# Altium schematic sheet glucometer_schematic.SchDoc
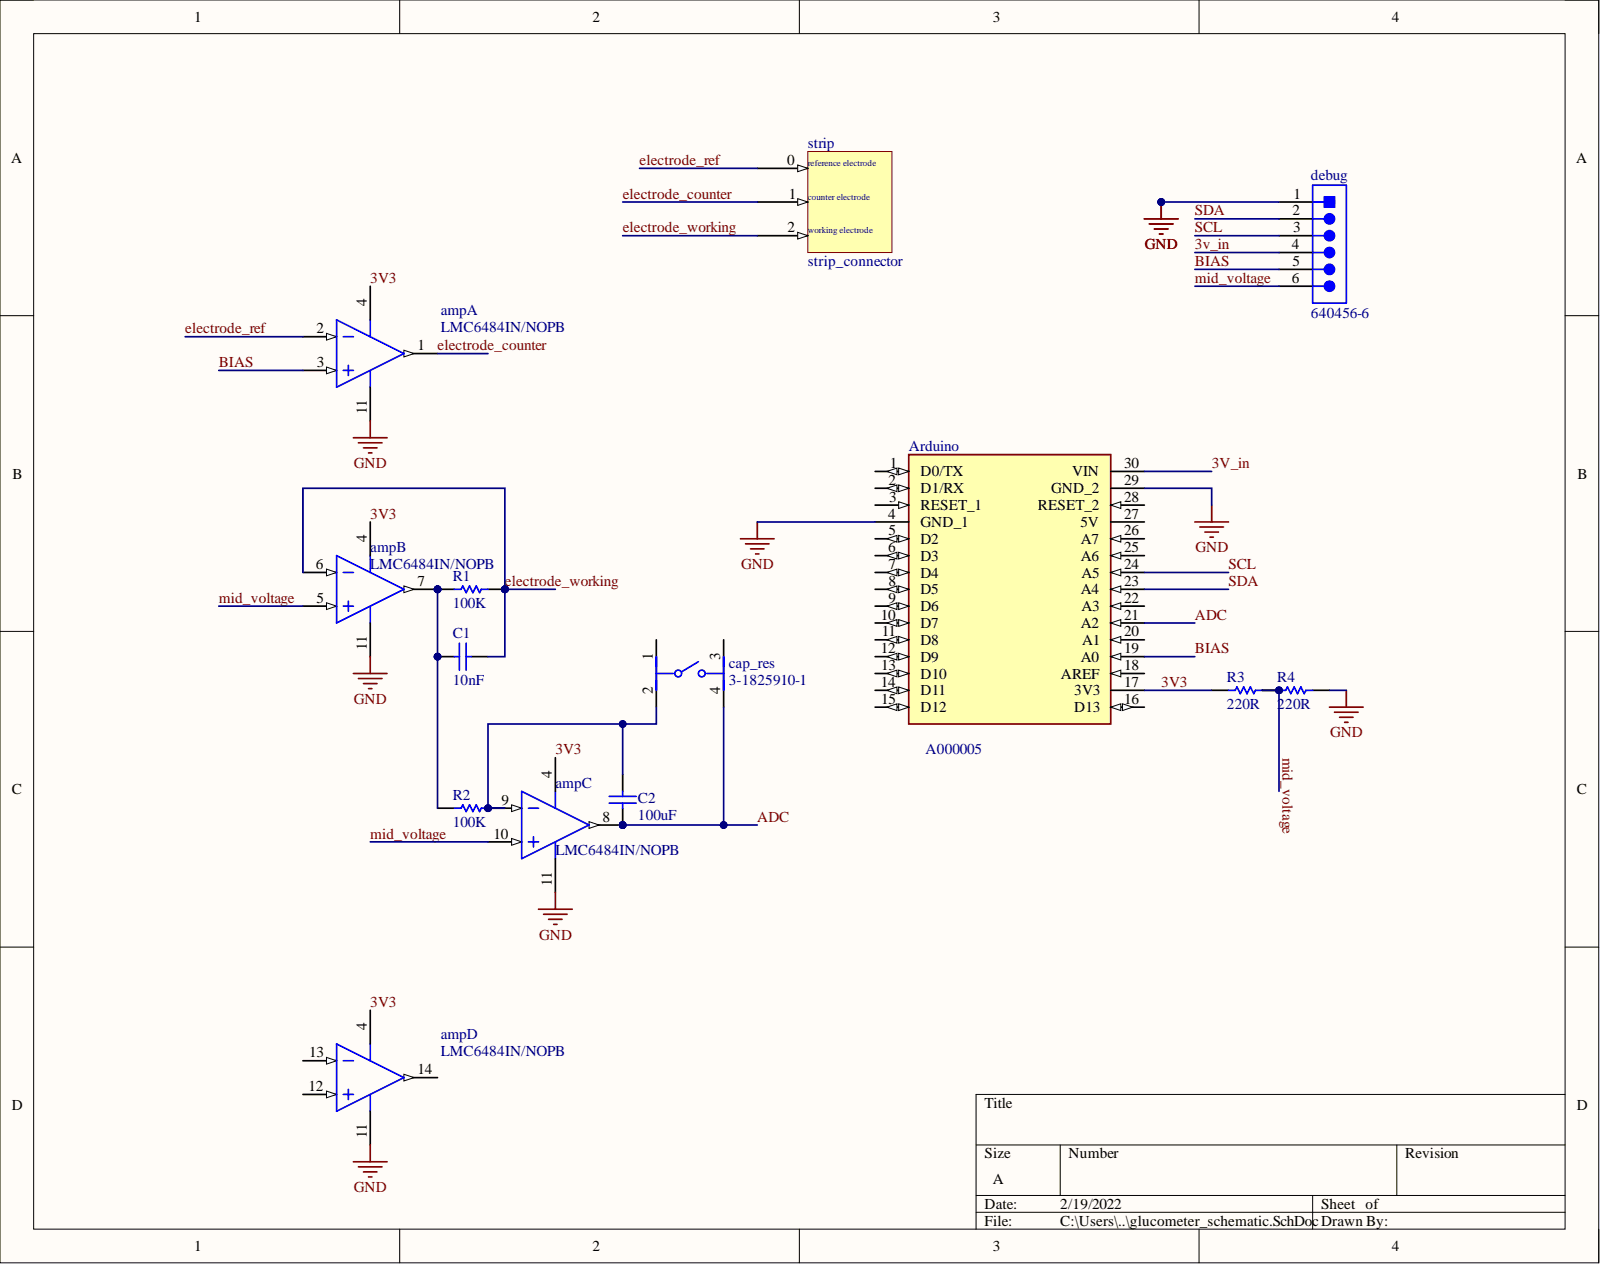
[diagram p0: glucometer_schematic.SchDoc]

## Parts
amp  LMC6484IN/NOPB  [NFF0014A]  (parts A,B,C,D)
Arduino  A000005  MF: Arduino  [MODULE_A000005]
C1  10nF  [KEMT-C330C105M5U5TA]
C2  100uF  [KEMT-C330C105M5U5TA]
cap_res  3-1825910-1  [FP-3-1825910-1-MFG]
debug  640456-6  [TECO-640456-6_V]
R1  100K  [RESA60-630X240]
R2  100K  [RESA60-630X240]
R3  220R  [RESA60-630X240]
R4  220R  [RESA60-630X240]
strip  strip_connector  [strip_connector]

## Nets
GND: amp.11 Arduino.4(GND_1) Arduino.29(GND_2) debug.1 R4.1
3V3: amp.4 Arduino.17(3V3) R3.2
3v_in: Arduino.30(VIN) debug.4
ADC: amp.8 Arduino.21(A2) C2.1 cap_res.4
BIAS: amp.3 Arduino.19(A0) debug.5
electrode_counter: amp.1 strip.1
electrode_ref: amp.2 strip.0
electrode_working: amp.6 C1.2 R1.2 strip.2
mid_voltage: amp.5 amp.10 debug.6 R3.1 R4.2
SCL: Arduino.24(A5) debug.3
SDA: Arduino.23(A4) debug.2
Netamp_7: amp.7 C1.1 R1.1 R2.1
Netamp_9: amp.9 C2.2 cap_res.2 R2.2

Not connected: amp 12 13 14; Arduino 1(D0/TX) 2(D1/RX) 3(RESET_1) 5(D2) 6(D3) 7(D4) 8(D5) 9(D6) 10(D7) 11(D8) 12(D9) 13(D10) 14(D11) 15(D12) 16(D13) 18(AREF) 20(A1) 22(A3) 25(A6) 26(A7) 27(5V) 28(RESET_2); cap_res 1 3

## Library data of the parts
amp = CMP-0055-00188-3: CMOS Quad Rail to Rail Input and Output Operational Amplifier, 14-pin MDIP, Pb-Free; Manufacturer: Texas Instruments; CompType: Amplifiers; PackageTopMarking: NSUZXYYTTE#*LMC6484IN; PowerWise: N; Code_JEDEC: MS-001-AA; Component Kind: Standard; ComponentLink1Description: Datasheet URL; ComponentLink1URL: http://www.ti.com/general/docs/lit/getliterature.tsp?genericPartNumber=LMC6484&fileType=pdf; Library Name: Altium Content Vault; Package Reference: NFF0014A; PackageDescription: MDIP, .750 x .250 x.130in, 14 Lead, .100in Pitch; PinCount: 14; RoHS: Y
Arduino = A000005: CLOCK SAW OSCILLATOR SEAM7050 T&; Component Kind: Standard; Digi-Key_Part_Number: SPA000005J-ND; Digi-Key_Purchase_URL: https://www.digikey.com/product-detail/en/diodes-incorporated/SPA000005J/SPA000005J-ND/9769570?utm_source=snapeda&utm_medium=aggregator&utm_campaign=symbol; MP: A000005; Package: None; SnapEDA_Link: https://www.snapeda.com/parts/A000005/Arduino/view-part/51027/?ref=snap; Library Name: glucometer_board_components.IntLib
C1 C2 = CMP-2000-06056-1: Ceramic Capacitor, 1 uF, +/- 20%, 50 V, -10 to 85 degC, 2-Pin THD, RoHS, Bulk; Manufacturer: Kemet; Capacitance: 1µF; Catalog Drawings: C330(std); Online Catalog: GoldenMax™Series; Other Names: 399-4390; PCN Design/Specification: C3yySeriesGoldenMaxLeadConfiguration02/Feb/2015; Product Training Modules: CapacitorBasics-TypicalUsesforCapacitors; Temperature Coefficient: Z5U; Voltage - Rated: 50V; Applications: GeneralPurpose; Category: Capacitors; Component Kind: Standard; Datasheet URL: http://www.kemet.com/Lists/ProductCatalog/Attachments/599/KEM_C1051_GOLDMAX_Z5U.pdf; Datasheet Version: 12/2015; Family: CeramicCapacitors; Features: -; Height - Seated (Max): 0.360"(9.14mm); Lead Spacing: 0.200"(5.08mm); Lead Style: Straight; Library Name: Altium Content Vault; Manufacturer URL: http://www.kemet.com/; Mounting Technology: ThroughHole; Mounting Type: ThroughHole; Operating Temperature: 10°C~85°C; Package / Case: Radial; Package Description: 2-Pin Through Hole Device, Body 7.11 x 4.07 mm; Package Reference: C330C105M5U5TA; Packaging: Bulk; Ratings: -; Series: GoldenMax™; Size / Dimension: 0.300"Lx0.150"W(7.62mmx3.81mm); Standard Package: 250; Thickness (Max): -; Tolerance: ±20%
cap_res = CMP-03407-000001-1: Switch Tactile OFF (ON) SPST Round Button PC Pins 0.05A 24VDC 100000Cycles 1.57N Thru-Hole; Manufacturer: TE Connectivity; Contact Current Rating: 50mA; Contact Resistance: 100mΩ; Operating Force: 160gf; Throw Configuration: SPST-NO; Automotive: No; Category: Switch; Component Kind: Standard; ComponentLink2Description: Datasheet; ComponentLink2URL: https://www.te.com/commerce/DocumentDelivery/DDEController?Action=srchrtrv&DocNm=1825910&DocType=Customer+Drawing&DocLang=English; Device Class L1: Electromechanical; Device Class L2: Switches; Device Class L3: Tactile Switches; Digikey Description: SWITCH TACTILE SPST-NO 0.05A 24V; Electromechanical Life: 100000Cycles; Height: 17.3mm; Lead Free: Yes; Library Name: Altium Content Vault; Manufacturer Part Number: 3-1825910-1; Mount: Through Hole; Octopart: https://octopart.com/3-1825910-1-te+connectivity-46506874; Package: 0; RoHS: TRUE; Temperature: -35°C to +85°C; Temperature Range High: +85°C; Temperature Range Low: -35°C
debug = CMP-2000-05661-1: Male Header, Pitch 2.54 mm, 1 x 6 Position, Height 10.03 mm, Tail Length 3.56 mm, -55 to 105 degC, Bulk; Manufacturer: TE Connectivity; Agency Approvals: CSA,UL; Angle: Straight; Brand/Series: MTA-100Series; Color, Housing: White; Connector Type: MTA-100; Contact Centerline Spacing: 0.100    in.; Contact Termination Area Plating: Tin; Current, Rating: 5    A; Material, Contact: CopperAlloy; Material, Housing: Polyester; Mount Angle: Vertical; Mounting Hole Size: 0.04    "; Number of Contacts: 6; Pin Spacing: 0.1    "; Primary Type: InterconnectSystem; Special Features: Pinstrip; Standards: RoHSCompliant,ELVCompliant,UL94V-0; Temperature, Operating: -55to+105    °C; Voltage, Rating: 250    V; Color: White; Component Kind: Standard; Contact Mating Area Plating: Tin; Contact Plating: Tin; Contact Shape: Square; Datasheet URL: https://datasheet.ciiva.com/25618/0900766b80eb7fdd-25618163.pdf; Datasheet Version: Rev. W, 05/2005; Flammability Rating: UL94V-0; Length, Overall: 0.6    "; Length, Pin: 0.14    "; Length, Terminal Post: 0.14    in.; Library Name: Altium Content Vault; Manufacturer URL: http://www.te.com/; Mounting Technology: ThroughHole; Mounting Type: PCMount; Number of Positions: 6; Number of Rows: 1; Package Description: Male Header, Pitch 2.54 mm, 1 x 6 Position, Height 10.03 mm, Tail Length 3.56 mm; Package Reference: 640456-6; Size, Post: 0.025    in.; Termination: Solder; Type: PCBPlug
R1-R4 = CMP-1659-00065-1: Axial Resistor, 2.21 KOhm, +/- 1%, 0.25 W, -55 to 155 degC, 2-Pin THD, RoHS, Bulk; Case\Package: 0402; Current Rating: 300 mA; Resistance: 0.15 Ohm; Shielding: Unshielded; Case Code (Imperial): 0402; Case Code (Metric): 1005; Component Kind: Standard; ComponentLink1Description: Manufacturer URL; ComponentLink1URL: http://www.yageo.com/; ComponentLink2Description: Datasheet; ComponentLink2URL: http://www.yageo.com/documents/recent/Yageo%20LR_MFR_2013.pdf; DatasheetVersion: Apr-13; Height: 0.02 inch; Length: 0.04 inch; Library Name: Altium Content Vault; Max Operating Temperature: 125 degC; Min Operating Temperature: -55 degC; Mount: Surface Mount; Package Quantity: 10000; PackageDescription: 2-Pin Axial Lead, Dia 2.4 mm, Body Length 6.3 mm; PackageReference: MFR-25; Packaging: Tape and Reel; Pins: 2; Radiation Hardening: No; REACH SVHC: No SVHC; RoHSCompliant: true; Width: 0.02 inch
strip = strip_connector: Component Kind: Standard; Library Name: glucometer_board_components.IntLib
